# Altium schematic sheet Power.SchDoc(Power)
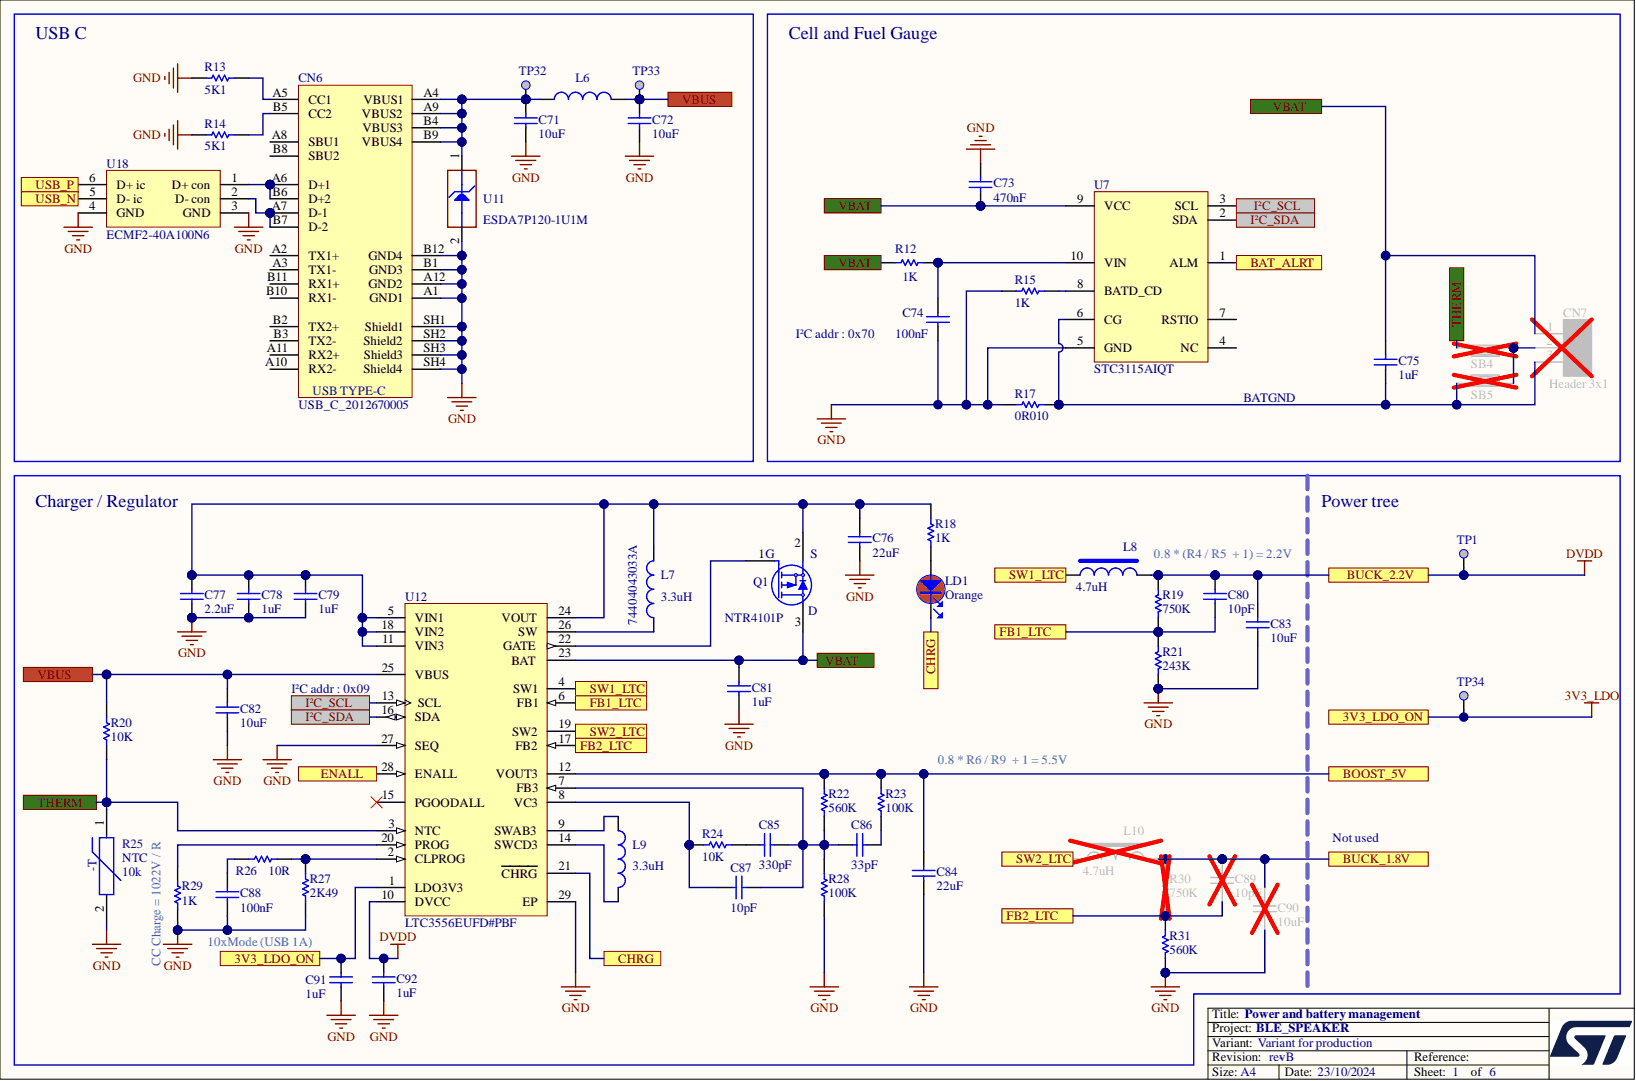
[diagram p0: Power.SchDoc]

## Parts
C71  value 10uF  [C0402]  (hidden comment: Capacitor)
C72  value 10uF  [C0402]  (hidden comment: Capacitor)
C73  value 470nF  [C0402]  (hidden comment: Capacitor)
C74  value 100nF  [C0201_H0P3]  (hidden comment: Capacitor)
C75  value 1uF  [C0402]  (hidden comment: Capacitor)
C76  value 22uF  [C0603_H0P8]  (hidden comment: Capacitor)
C77  value 2.2uF  [C0402]  (hidden comment: Capacitor)
C78  value 1uF  [C0402]  (hidden comment: Capacitor)
C79  value 1uF  [C0402]  (hidden comment: Capacitor)
C80  value 10pF  [IND_0201_MURATA_GJM03_H0P3]  (hidden comment: Capacitor)
C81  value 1uF  [C0402]  (hidden comment: Capacitor)
C82  value 10uF  [C0402]  (hidden comment: Capacitor)
C83  value 10uF  [C0402]  (hidden comment: Capacitor)
C84  value 22uF  [C0603_H0P8]  (hidden comment: Capacitor)
C85  value 330pF  [C0402]  (hidden comment: Capacitor)
C86  value 33pF  [C0402]  (hidden comment: Capacitor)
C87  value 10pF  [IND_0201_MURATA_GJM03_H0P3]  (hidden comment: Capacitor)
C88  value 100nF  [C0201_H0P3]  (hidden comment: Capacitor)
C89  value 10pF  [IND_0201_MURATA_GJM03_H0P3]  (hidden comment: Capacitor)
C90  value 10uF  [C0402]  (hidden comment: Capacitor)
C91  value 1uF  [C0402]  (hidden comment: Capacitor)
C92  value 1uF  [C0402]  (hidden comment: Capacitor)
CN6  value USB_C_2012670005  [CON_USB-TYPEC_MOLEX_2012670005]  (hidden comment: USB_TYPE_C_Receptacle)
CN7  Header 3x1  [CON_988-JIUBABA_PHFS25-11-03-G0B115-T-1]
L6  BEAD  [FIL_0603_H0P65]  (value not drawn)
L7  value 3.3uH  Manufacturer_Part_Number: 74404043033A  [IND_4025_WURTH_74404043022A]  (hidden comment: Inductor)
L8  value 4.7uH  [IND_TAIYO-YUDEN_LSXND4040]  (hidden comment: INDUCTOR_POWER)
L9  value 3.3uH  [IND_4025_WURTH_74404043022A]  (hidden comment: Inductor)
L10  value 4.7uH  [IND_TAIYO-YUDEN_LSXND4040]  (hidden comment: INDUCTOR_POWER)
LD1  value Orange  [LED_0603_VISHAY_VLMO1300-GS08_ORANGE_1A2K_H0P8]  (hidden comment: LED_orange)
Q1  value NTR4101P  [MOS_SOT23]  (hidden comment: MOSFET_P-CHANNEL)
R12  value 1K  [R0402]  (hidden comment: Resistor)
R13  value 5K1  [R0402]  (hidden comment: Resistor)
R14  value 5K1  [R0402]  (hidden comment: Resistor)
R15  value 1K  [R0402]  (hidden comment: Resistor)
R17  value 0R010  [R1206_H0P65]  (hidden comment: Resistor)
R18  value 1K  [R0402]  (hidden comment: Resistor)
R19  value 750K  [R0402]  (hidden comment: Resistor)
R20  value 10K  [R0402]  (hidden comment: Resistor)
R21  value 243K  [R0402]  (hidden comment: Resistor)
R22  value 560K  [R0402]  (hidden comment: Resistor)
R23  value 100K  [R0402]  (hidden comment: Resistor)
R24  value 10K  [R0402]  (hidden comment: Resistor)
R25  NTC  value 10k  [NTC0201_H0P3]
R26  value 10R  [R0402]  (hidden comment: Resistor)
R27  value 2K49  [R0402]  (hidden comment: Resistor)
R28  value 100K  [R0402]  (hidden comment: Resistor)
R29  value 1K  [R0402]  (hidden comment: Resistor)
R30  value 750K  [R0402]  (hidden comment: Resistor)
R31  value 560K  [R0402]  (hidden comment: Resistor)
SB4  SB_ON  [SOLDER-BRIDGE_R0201_0R]  (value not drawn)
SB5  SB_ON  [SOLDER-BRIDGE_R0201_0R]  (value not drawn)
TP1  Test Point  [TP_SMD_1MM]  (value not drawn)  (no BOM)
TP32  Test Point  [TP_SMD_1MM]  (value not drawn)  (no BOM)
TP33  Test Point  [TP_SMD_1MM]  (value not drawn)  (no BOM)
TP34  Test Point  [TP_SMD_1MM]  (value not drawn)  (no BOM)
U7  STC3115AIQT  [ICC_DFN10_3X2_P050]
U11  value ESDA7P120-1U1M  Manufacturer_Part_Number: ESDA7P120-1U1M  [ESD_QFN1610_2N_1K_2A]  (hidden comment: ESD-TRANSIL)
U12  LTC3556EUFD#PBF  [LT-UFD-28_M]
U18  ECMF2-40A100N6  [ESD_UQFN-6L_1P45X1P4_P040]

## Ports
3V3_LDO_ON, BAT_ALRT, BOOST_5V, BUCK_1.8V, BUCK_2.2V, CHRG, ENALL, FB1_LTC, FB2_LTC, I²C_SCL, I²C_SDA, SW1_LTC, SW2_LTC, THERM, USB_N, USB_P, VBAT, VBUS

## Nets
GND: C71.1 C72.1 C73.1 C74.2 C76.1 C77.1 C78.1 C79.2 C81.2 C82.1 C83.1 C84.1 C88.2 C90.1 C91.2 C92.2 CN6.A1(GND1) CN6.A12(GND2) CN6.B1(GND3) CN6.B12(GND4) CN6.SH1(Shield1) CN6.SH2(Shield2) CN6.SH3(Shield3) CN6.SH4(Shield4) R13.2 R14.1 R15.2 R17.1 R21.1 R25.2 R27.1 R28.1 R29.1 R31.1 U7.5(GND) U11.2 U12.27(SEQ) U12.29(EP) U18.3(GND) U18.4(GND)
3V3_LDO: C91.1 TP34.1 U12.1(LDO3V3) ; port 3V3_LDO_ON
BAT_ALRT: U7.1(ALM) ; port BAT_ALRT
BOOST_5V: C84.2 R22.2 R23.1 U12.12(VOUT3) ; port BOOST_5V
BUCK_1.8V: C89.1 C90.2 L10.1 R30.1 ; port BUCK_1.8V
CHRG: LD1.2 U12.21(CHRG) ; port CHRG
DVDD: C80.1 C83.2 C92.1 L8.1 R19.1 TP1.1 U12.10(DVCC) ; port BUCK_2.2V
ENALL: U12.28(ENALL) ; port ENALL
FB1_LTC: C80.2 R19.2 R21.2 U12.6(FB1) ; port FB1_LTC
FB2_LTC: C89.2 R30.2 R31.2 U12.17(FB2) ; port FB2_LTC
I²C_SCL: U7.3(SCL) U12.13(SCL) ; port I²C_SCL
I²C_SDA: U7.2(SDA) U12.16(SDA) ; port I²C_SDA
SW1_LTC: L8.2 U12.4(SW1) ; port SW1_LTC
SW2_LTC: L10.2 U12.19(SW2) ; port SW2_LTC
THERM: R20.1 R25.1 SB4.1 U12.3(NTC) ; port THERM
USB_N: U18.5(D-_ic) ; port USB_N
USB_P: U18.6(D+_ic) ; port USB_P
VBAT: C73.2 C75.1 C81.1 CN7.1 Q1.3 R12.2 U7.9(VCC) U12.23(BAT) ; port VBAT
VBUS: C72.2 C82.2 L6.2 R20.2 TP33.1 U12.25(VBUS) ; port VBUS
NetC71_2: C71.2 CN6.A4(VBUS1) CN6.A9(VBUS2) CN6.B4(VBUS3) CN6.B9(VBUS4) L6.1 TP32.1 U11.1
NetC74_1: C74.1 R12.1 U7.10(VIN)
NetC75_2: C75.2 CN7.3 R17.2 SB5.2 U7.6(CG)
NetC76_2: C76.2 C77.2 C78.2 C79.1 L7.2 Q1.2 R18.2 U12.5(VIN1) U12.11(VIN3) U12.18(VIN2) U12.24(VOUT)
NetC85_1: C85.1 R24.2
NetC85_2: C85.2 C86.2 C87.1 R22.1 R28.2 U12.7(FB3)
NetC86_1: C86.1 R23.2
NetC87_2: C87.2 R24.1 U12.8(VC3)
NetC88_1: C88.1 R26.1
NetCN6_A5: CN6.A5(CC1) R13.1
NetCN6_A6: CN6.A6(D+1) CN6.B6(D+2) U18.1(D+_con)
NetCN6_A7: CN6.A7(D-1) CN6.B7(D-2) U18.2(D-_con)
NetCN6_B5: CN6.B5(CC2) R14.2
NetCN7_2: CN7.2 SB4.2 SB5.1
NetL7_1: L7.1 U12.26(SW)
NetL9_1: L9.1 U12.9(SWAB3)
NetL9_2: L9.2 U12.14(SWCD3)
NetLD1_1: LD1.1 R18.1
NetQ1_1: Q1.1 U12.22(GATE)
NetR15_1: R15.1 U7.8(BATD_CD)
NetR26_2: R26.2 R27.2 U12.2(CLPROG)
NetR29_2: R29.2 U12.20(PROG)

Not connected: CN6 A2(TX1+) A3(TX1-) A8(SBU1) A10(RX2-) A11(RX2+) B2(TX2+) B3(TX2-) B8(SBU2) B10(RX1-) B11(RX1+); U7 4(NC) 7(RSTIO); U12 15(PGOODALL)

## Library data of the parts
C71 C72 C82 C83 C90 = MCD-03140: Capacitor, MLCC, 10uF, 6.3V, X5R, +/-20%, 0402, T=0.5mm; Manufacturer: MURATA; Manufacturer_Part_Number: GRM155R60J106ME05D; Part_Preference: Recommended; Component_Country_Of_Origin: China, Japan; Database Table Name: MCD_PARTS$; ID: 5256; ShowFields: YES; Component Kind: Standard; ComponentLink1URL: http://tunmcdsvn.tun.st.com/Component_Documentation_Library/Trunk/Capacitor/MURATA_GRM_Series; Internal_Part_Number: MCD-03140; Library Name: MCD_PARTS_database.DbLib; Order_Code_Digikey: 490-GRM155R60J106ME05DTR-ND; Order_Code_Farnell: 3784428; Order_Code_RS: N/A; Package: 0402; Part_Type: Capacitor; Status: Released
C73 = MCD-03075: Capacitor, MLCC, 470nF, 25V, X5R, +/-10%, 0402, T=0.5mm; Manufacturer: YAGEO; Manufacturer_Part_Number: CC0402KRX5R8BB474; Part_Preference: Recommended; Component_Country_Of_Origin: China, Taiwan; Database Table Name: MCD_PARTS$; ID: 5191; ShowFields: YES; Component Kind: Standard; ComponentLink1URL: http://tunmcdsvn.tun.st.com/Component_Documentation_Library/Trunk/Capacitor/YAGEO_X5R_Series/; Internal_Part_Number: MCD-03075; Library Name: MCD_PARTS_database.DbLib; Order_Code_Digikey: 13-CC0402KRX5R8BB474TR-ND; Order_Code_Farnell: 3871700; Order_Code_RS: N/A; Package: 0402; Part_Type: Capacitor; Status: Checked
C74 C88 = MCD-00697: Capacitor, MLCC, 100nF,10V, X5R, +/-10%, 0201, T=0.3mm; Manufacturer: TDK; Manufacturer_Part_Number: C0603X5R1A104K030BC; Part_Preference: Recommended; Component_Country_Of_Origin: Unknown; Database Table Name: MCD_PARTS$; ID: 3046; ShowFields: YES; Component Kind: Standard; ComponentLink1URL: http://tunmcdsvn.tun.st.com/Component_Documentation_Library/Trunk/Capacitor/TDK_MCC_Series; Internal_Part_Number: MCD-00697; Library Name: MCD_PARTS_database.DbLib; Order_Code_Digikey: 445-7318-1-ND; Order_Code_Farnell: 2524957; Order_Code_RS: N/A; Package: 0201; Part_Type: Capacitor; Status: Released
C75 C78 C79 C81 C91 C92 = MCD-01722: Capacitor, MLCC, 1uF, 10V, X5R, +/-10%, 0402, T=0.5mm; Manufacturer: YAGEO; Manufacturer_Part_Number: CC0402KRX5R6BB105; Part_Preference: Recommended; Component_Country_Of_Origin: China; Database Table Name: MCD_PARTS$; ID: 3822; ShowFields: YES; Component Kind: Standard; ComponentLink1URL: http://tunmcdsvn.tun.st.com/Component_Documentation_Library/Trunk/Capacitor/YAGEO_X5R_Series; Internal_Part_Number: MCD-01722; Library Name: MCD_PARTS_database.DbLib; Order_Code_Digikey: 311-1439-1-ND; Order_Code_Farnell: 1458896; Order_Code_RS: N/A; Package: 0402; Part_Type: Capacitor; Status: Released
C76 C84 = MCD-02607: Capacitor, MLCC, 22uF, 10V, X5R, +/-20%, 0603, T=0.8mm; Manufacturer: YAGEO; Manufacturer_Part_Number: CC0603MRX5R6BB226; Part_Preference: Recommended; Component_Country_Of_Origin: Taiwan°; Database Table Name: MCD_PARTS$; ID: 4713; Order_Code_RS: 2006627; ShowFields: YES; Component Kind: Standard; ComponentLink1URL: http://tunmcdsvn.tun.st.com/Component_Documentation_Library/Trunk/Capacitor/YAGEO_X5R_Series; Internal_Part_Number: MCD-02607; Library Name: MCD_PARTS_database.DbLib; Order_Code_Digikey: 311-1819-2-ND; Order_Code_Farnell: N/A; Package: 0603; Part_Type: Capacitor; Status: Released
C77 = MCD-01823: Capacitor, MLCC, 2.2uF, 6V3, X5R,+/-10%, 0402, T=0.5mm; Manufacturer: MURATA; Manufacturer_Part_Number: GRM155R60J225KE95D; Part_Preference: Recommended; Partial_Alternate_Internal_Part_Number: MCD-03566; Component_Country_Of_Origin: Japan°°; Database Table Name: MCD_PARTS$; ID: 3923; Order_Code_RS: 172-6478; ShowFields: YES; Component Kind: Standard; ComponentLink1URL: http://tunmcdsvn.tun.st.com/Component_Documentation_Library/Trunk/Capacitor/MURATA_GRM_Series; Internal_Part_Number: MCD-01823; Library Name: MCD_PARTS_database.DbLib; Order_Code_Digikey: 490-12532-1-ND; Order_Code_Farnell: 2695865; Package: 0402; Part_Type: Capacitor; Status: Released
C80 C87 C89 = MCD-04016: Capacitor, MLCC, 10pF, 25V, NPO, +/-5%, 0201, T=0.3mm; Manufacturer: MURATA; Manufacturer_Part_Number: GJM0335C1E100JB01; Part_Preference: Recommended; Component_Country_Of_Origin: Japan, Singapore; Database Table Name: MCD_PARTS$; ID: 6132; ShowFields: YES; Component Kind: Standard; ComponentLink1URL: http://tunmcdsvn.tun.st.com/Component_Documentation_Library/Trunk/Capacitor/MURATA_GJM_Series/GJM0335C1E100GB01D; Internal_Part_Number: MCD-04016; Library Name: MCD_PARTS_database.DbLib; Order_Code_Digikey: 490-13312-6-ND; Order_Code_Farnell: 3346154; Order_Code_RS: N/A; Package: 0201; Part_Type: Capacitor; Status: Checked
C85 = MCD-01579: Capacitor, MLCC, 330pF, 50V, NPO, +/-5%, 0402, T=0.5mm; Manufacturer: YAGEO; Manufacturer_Part_Number: CC0402JRNPO9BN331; Part_Preference: Recommended; Component_Country_Of_Origin: China; Database Table Name: MCD_PARTS$; ID: 3679; ShowFields: YES; Component Kind: Standard; ComponentLink1URL: http://tunmcdsvn.tun.st.com/Component_Documentation_Library/Trunk/Capacitor/YAGEO_NP0_Series; Internal_Part_Number: MCD-01579; Library Name: MCD_PARTS_database.DbLib; Order_Code_Digikey: 311-1667-1-ND; Order_Code_Farnell: N/A; Order_Code_RS: N/A; Package: 0402; Part_Type: Capacitor; Status: Released
C86 = MCD-00277: Capacitor, MLCC, 33pF, 50V, NPO,+/-5%, 0402, T=0.5mm; Manufacturer: YAGEO; Manufacturer_Part_Number: CC0402JRNPO9BN330; Part_Preference: Recommended; Component_Country_Of_Origin: China; Database Table Name: MCD_PARTS$; ID: 2592; ShowFields: YES; Component Kind: Standard; ComponentLink1URL: http://tunmcdsvn.tun.st.com/Component_Documentation_Library/Trunk/Capacitor/YAGEO_NP0_Series; Internal_Part_Number: MCD-00277; Library Name: MCD_PARTS_database.DbLib; Order_Code_Digikey: 311-1020-1-ND; Order_Code_Farnell: 3019196; Order_Code_RS: N/A; Package: 0402; Part_Type: Capacitor; Status: Released
CN6 = MCD-02421: USB_TypeC, 24 pins, RA, SMD, T=3.71mm (USB Certified 3.1); Manufacturer: MOLEX; Manufacturer_Part_Number: 2012670005; Part_Preference: Recommended; Part_Type: Connector; Component_Country_Of_Origin: Unknown; Database Table Name: MCD_PARTS$; ID: 4527; Order_Code_RS: 176-8434; ShowFields: YES; Component Kind: Standard; ComponentLink1URL: http://tunmcdsvn.tun.st.com/Component_Documentation_Library/Trunk/Connector/MOLEX_201267_Series; Internal_Part_Number: MCD-02421; Library Name: MCD_PARTS_database.DbLib; Order_Code_Digikey: WM17992TR-ND; Order_Code_Farnell: 2990523; Status: Released
CN7 = MCD-00315: Header, 3x1, 2.54mm, VR, TH, T=6mm/3mm; Manufacturer: JIUBABA; Manufacturer_Part_Number: PHFS25-11-03-G0B115-T-1; Part_Preference: Alternate; Part_Type: Connector; Alternate_EMS: A; Component_Country_Of_Origin: Unknown; Database Table Name: MCD_PARTS$; ID: 2555; ShowFields: YES; Component Kind: Standard; ComponentLink1URL: http://tunmcdsvn.tun.st.com/Component_Documentation_Library/Trunk/Connector/JIUBABA_PHFS25-11-03-G0B115-T-1/; Internal_Part_Number: MCD-00315; Library Name: MCD_PARTS_database.DbLib; Order_Code_Digikey: N/A; Order_Code_Farnell: N/A; Order_Code_RS: N/A; Status: Released; Value: Header 3x1
L6 = MCD-00305: Ferrite bead, 26R @ 100Mhz, +/-25%, 6000mA, 0R007, 0603, T=0.75mm; Manufacturer: MURATA; Manufacturer_Part_Number: BLM18KG260TN1; Part_Preference: Recommended; Part_Type: Inductor; Component_Country_Of_Origin: Japan°°; Database Table Name: MCD_PARTS$; ID: 2564; Order_Code_RS: 747-4723; ShowFields: YES; Component Kind: Standard; ComponentLink1URL: http://tunmcdsvn.tun.st.com/Component_Documentation_Library/Trunk/Inductor/MURATA_BLM_Series; Internal_Part_Number: MCD-00305; Library Name: MCD_PARTS_database.DbLib; Order_Code_Digikey: 490-5252-2-ND; Order_Code_Farnell: 1781087; Package: 0603; Status: Released; Value: 26R @ 100Mhz
L7 = MCD-02242: Inductor, 3.3uH, +/-30%, 3,83A, 0R023, 4x4mm, T=2.5mm; Manufacturer: WURTH ELEKTRONIK; Part_Preference: Recommended; Component_Country_Of_Origin: Unknown; Database Table Name: MCD_PARTS$; ID: 4342; ShowFields: YES; Component Kind: Standard; ComponentLink1URL: http://tunmcdsvn.tun.st.com/Component_Documentation_Library/Trunk/Inductor/WURTH_ELEKTRONIK_74404043022A; Internal_Part_Number: MCD-02242; Library Name: MCD_PARTS_database.DbLib; Order_Code_Digikey: 732-10754-2-ND; Order_Code_Farnell: 2749069; Order_Code_RS: N/A; Package: 4x4mm; Part_Type: Inductor; Status: Released
L8 L10 = MCD-04038: Inductor, 4.7uH, +/-20%, 1.2A, 114mR, 4040, T=1.2mm; Manufacturer: TAIYO YUDEN; Manufacturer_Part_Number: LSXND4040MKL4R7MDG; Part_Preference: Recommended; Component_Country_Of_Origin: Unknown; Database Table Name: MCD_PARTS$; ID: 6154; ShowFields: YES; Component Kind: Standard; ComponentLink1URL: http://tunmcdsvn.tun.st.com/Component_Documentation_Library/Trunk/Inductor/TAIYO_YUDEN_NR_Series/LSXND4040MKL4R7MDG; Internal_Part_Number: MCD-04038; Library Name: MCD_PARTS_database.DbLib; Order_Code_Digikey: 587-LSXND4040MKL4R7MDGTR-ND; Order_Code_Farnell: N/A; Order_Code_RS: N/A; Package: 4040; Part_Type: Inductor; Status: Checked
L9 = MCD-02242: Inductor, 3.3uH, +/-30%, 3,83A, 0R023, 4x4mm, T=2.5mm; Manufacturer: WURTH ELEKTRONIK; Manufacturer_Part_Number: 74404043033A; Part_Preference: Recommended; Component_Country_Of_Origin: Unknown; Database Table Name: MCD_PARTS$; ID: 4342; ShowFields: YES; Component Kind: Standard; ComponentLink1URL: http://tunmcdsvn.tun.st.com/Component_Documentation_Library/Trunk/Inductor/WURTH_ELEKTRONIK_74404043022A; Internal_Part_Number: MCD-02242; Library Name: MCD_PARTS_database.DbLib; Order_Code_Digikey: 732-10754-2-ND; Order_Code_Farnell: 2749069; Order_Code_RS: N/A; Package: 4x4mm; Part_Type: Inductor; Status: Released
LD1 = MCD-00591: LED, Orange, 2V, 20mA, 0603; Manufacturer: VISHAY; Manufacturer_Part_Number: VLMO1300-GS08; Part_Preference: Recommended; Part_Type: Display; Component_Country_Of_Origin: China; Database Table Name: MCD_PARTS$; ID: 3153; Order_Code_RS: 7730446; ShowFields: YES; Component Kind: Standard; ComponentLink1URL: http://tunmcdsvn.tun.st.com/Component_Documentation_Library/Trunk/Display/VISHAY_VLMO1300-GS08; Internal_Part_Number: MCD-00591; Library Name: MCD_PARTS_database.DbLib; Order_Code_Digikey: VLMO1300-GS08CT-ND; Order_Code_Farnell: 2251473; Package: 0603; Status: Released
Q1 = MCD-02288: Transistor, MOSFET, P-CH, -20V, -3.2A, SOT23-3; Manufacturer: ON SEMICONDUCTOR; Manufacturer_Part_Number: NTR4101PT1G; Part_Preference: Recommended; Component_Country_Of_Origin: China°; Database Table Name: MCD_PARTS$; ID: 4388; ShowFields: YES; Component Kind: Standard; ComponentLink1URL: http://tunmcdsvn.tun.st.com/Component_Documentation_Library/Trunk/Transistor/ON_SEMICONDUCTOR_NTR4101PT1G; Internal_Part_Number: MCD-02288; Library Name: MCD_PARTS_database.DbLib; Order_Code_Digikey: NTR4101PT1GOSCT-ND; Order_Code_Farnell: 1431303; Order_Code_RS: N/A; Package: SOT23-3; Part_Type: Transistor; Status: Released
R12 R15 R18 R29 = MCD-00061: Resistor, 1K, 0402, +/-1%,1/16W; Manufacturer: YAGEO; Manufacturer_Part_Number: RC0402FR-071KL; Part_Preference: Recommended; Component_Country_Of_Origin: China; Database Table Name: MCD_PARTS$; ID: 2532; Order_Code_RS: 9239235; ShowFields: YES; Component Kind: Standard; ComponentLink1URL: http://tunmcdsvn.tun.st.com/Component_Documentation_Library/Trunk/Resistor/YAGEO_RC_L_Series; Internal_Part_Number: MCD-00061; Library Name: MCD_PARTS_database.DbLib; Order_Code_Digikey: 311-1.00KLRCT-ND; Order_Code_Farnell: 9239235; Package: 0402; Part_Type: Resistor; Status: Released
R13 R14 = MCD-00333: Resistor, 5K1, 0402, +/-1%, 1/16W; Manufacturer: YAGEO; Manufacturer_Part_Number: RC0402FR-075K1L; Part_Preference: Recommended; Component_Country_Of_Origin: China; Database Table Name: MCD_PARTS$; ID: 2944; ShowFields: YES; Component Kind: Standard; ComponentLink1URL: http://tunmcdsvn.tun.st.com/Component_Documentation_Library/Trunk/Resistor/YAGEO_RC_L_Series; Internal_Part_Number: MCD-00333; Library Name: MCD_PARTS_database.DbLib; Order_Code_Digikey: 311-5.10KLRCT-ND; Order_Code_Farnell: 1458805; Order_Code_RS: N/A; Package: 0402; Part_Type: Resistor; Status: Released
R17 = MCD-01029: Resistor, 0R010, 1206 ,+/-1%, 1W; Manufacturer: PANASONIC; Manufacturer_Part_Number: ERJ8CWFR015V; Part_Preference: Recommended; Component_Country_Of_Origin: Japan; Database Table Name: MCD_PARTS$; ID: 2994; Order_Code_RS: 763-8744; ShowFields: YES; Component Kind: Standard; ComponentLink1URL: http://tunmcdsvn.tun.st.com/Component_Documentation_Library/Trunk/Resistor/PANASONIC_ERJ_Series; Internal_Part_Number: MCD-01029; Library Name: MCD_PARTS_database.DbLib; Order_Code_Digikey: P0.015BVCT-ND; Order_Code_Farnell: 2145288; Package: 1206; Part_Type: Resistor; Status: Checked
R19 R30 = MCD-00523: Resistor, 750K, 0402, +/-1%, 1/16W; Manufacturer: YAGEO; Manufacturer_Part_Number: RC0402FR-07750KL; Part_Preference: Recommended; Component_Country_Of_Origin: China; Database Table Name: MCD_PARTS$; ID: 3219; ShowFields: YES; Component Kind: Standard; ComponentLink1URL: http://tunmcdsvn.tun.st.com/Component_Documentation_Library/Trunk/Resistor/YAGEO_RC_L_Series; Internal_Part_Number: MCD-00523; Library Name: MCD_PARTS_database.DbLib; Order_Code_Digikey: YAG3228CT-ND; Order_Code_Farnell: 1458827; Order_Code_RS: N/A; Package: 0402; Part_Type: Resistor; Status: Released
R20 R24 = MCD-00053: Resistor, 10K, 0402, +/-1%, 1/16W; BMS_Part_Number: 9131590; Manufacturer: YAGEO; Manufacturer_Part_Number: RC0402FR-0710KL; Part_Preference: Recommended; Component_Country_Of_Origin: China; Database Table Name: MCD_PARTS$; ID: 2539; Order_Code_RS: 9239359; ShowFields: YES; Component Kind: Standard; ComponentLink1URL: http://tunmcdsvn.tun.st.com/Component_Documentation_Library/Trunk/Resistor/YAGEO_RC_L_Series; Internal_Part_Number: MCD-00053; Library Name: MCD_PARTS_database.DbLib; Order_Code_Digikey: 311-10.0KLRCT-ND; Order_Code_Farnell: 9239359; Package: 0402; Part_Type: Resistor; Status: Released
R21 = MCD-00479: Resistor, 430K, 0402, +/-1%, 1/16W; Manufacturer: YAGEO; Manufacturer_Part_Number: RC0402FR-07430KL; Part_Preference: Recommended; Component_Country_Of_Origin: China; Database Table Name: MCD_PARTS$; ID: 2799; ShowFields: YES; Component Kind: Standard; ComponentLink1URL: http://tunmcdsvn.tun.st.com/Component_Documentation_Library/Trunk/Resistor/YAGEO_RC_L_Series; Internal_Part_Number: MCD-00479; Library Name: MCD_PARTS_database.DbLib; Order_Code_Digikey: 311-430KLRCT-ND; Order_Code_Farnell: N/A; Order_Code_RS: N/A; Package: 0402; Part_Type: Resistor; Status: Released
R22 R31 = MCD-00495: Resistor, 560K, 0402, +/-1%, 1/16W; Manufacturer: YAGEO; Manufacturer_Part_Number: RC0402FR-07560KL; Part_Preference: Recommended; Component_Country_Of_Origin: China; Database Table Name: MCD_PARTS$; ID: 2779; ShowFields: YES; Component Kind: Standard; ComponentLink1URL: http://tunmcdsvn.tun.st.com/Component_Documentation_Library/Trunk/Resistor/YAGEO_RC_L_Series; Internal_Part_Number: MCD-00495; Library Name: MCD_PARTS_database.DbLib; Order_Code_Digikey: 311-560KLRCT-ND; Order_Code_Farnell: 1458810; Order_Code_RS: N/A; Package: 0402; Part_Type: Resistor; Status: Released
R23 R28 = MCD-00059: Resistor, 100K, 0402, +/-1%, 1/16W; Manufacturer: YAGEO; Manufacturer_Part_Number: RC0402FR-07100KL; Part_Preference: Recommended; Partial_Alternate_Internal_Part_Number: MCD-03301; Component_Country_Of_Origin: China; Database Table Name: MCD_PARTS$; ID: 2533; Order_Code_RS: 2421891; ShowFields: YES; Component Kind: Standard; ComponentLink1URL: http://tunmcdsvn.tun.st.com/Component_Documentation_Library/Trunk/Resistor/YAGEO_RC_L_Series; Internal_Part_Number: MCD-00059; Library Name: MCD_PARTS_database.DbLib; Order_Code_Digikey: 311-100KLRTR-ND; Order_Code_Farnell: 2421891; Package: 0402; Part_Type: Resistor; Status: Released
R25 = MCD-03760: NTC Thermistor, 10k, 0201, +/-1%@25°C, 100mW; Manufacturer: TDK; Manufacturer_Part_Number: NTCG063JF103FTDS; Part_Preference: Recommended; Part_Type: Resistor; Component_Country_Of_Origin: Japan°; Database Table Name: MCD_PARTS$; ID: 5876; ShowFields: YES; Component Kind: Standard; ComponentLink1URL: http://tunmcdsvn.tun.st.com/Component_Documentation_Library/Trunk/Resistor/TDK_NTCG_Series/NTCG063JF103FTDS; Internal_Part_Number: MCD-03760; Library Name: MCD_PARTS_database.DbLib; Order_Code_Digikey: N/A; Order_Code_Farnell: 4154099; Order_Code_RS: N/A; Package: 0201; Status: Checked
R26 = MCD-00358: Resistor, 10R, 0402, +/-1%, 1/16W; Manufacturer: YAGEO; Manufacturer_Part_Number: RC0402FR-0710RL; Part_Preference: Recommended; Component_Country_Of_Origin: China; Database Table Name: MCD_PARTS$; ID: 2919; Order_Code_RS: 618-5848; ShowFields: YES; Component Kind: Standard; ComponentLink1URL: http://tunmcdsvn.tun.st.com/Component_Documentation_Library/Trunk/Resistor/YAGEO_RC_L_Series; Internal_Part_Number: MCD-00358; Library Name: MCD_PARTS_database.DbLib; Order_Code_Digikey: 311-10.0LRCT-ND; Order_Code_Farnell: 9238999; Package: 0402; Part_Type: Resistor; Status: Released
R27 = MCD-00183: Resistor, 2K49, 0402, +/-1%, 1/16W; Manufacturer: YAGEO; Manufacturer_Part_Number: RC0402FR-072K49L; Part_Preference: Recommended; Component_Country_Of_Origin: China; Database Table Name: MCD_PARTS$; ID: 2687; ShowFields: YES; Component Kind: Standard; ComponentLink1URL: http://tunmcdsvn.tun.st.com/Component_Documentation_Library/Trunk/Resistor/YAGEO_RC_L_Series; Internal_Part_Number: MCD-00183; Library Name: MCD_PARTS_database.DbLib; Order_Code_Digikey: 311-2.49KLRCT-ND; Order_Code_Farnell: 1799190; Order_Code_RS: N/A; Package: 0402; Part_Type: Resistor; Status: Released
SB4 SB5 = MCD-03219: Solder Bridge ON, 0R, 0201, 1/20W; Manufacturer: YAGEO; Manufacturer_Part_Number: RC0201JR-070RL; Part_Preference: Recommended; Part_Type: Misc; Component_Country_Of_Origin: China; Database Table Name: MCD_PARTS$; ID: 5335; Order_Code_RS: 198-7623; ShowFields: YES; Component Kind: Standard; ComponentLink1URL: http://tunmcdsvn.tun.st.com/Component_Documentation_Library/Trunk/Resistor/YAGEO_RC_L_Series; Internal_Part_Number: MCD-03219; Library Name: MCD_PARTS_database.DbLib; Order_Code_Digikey: 311-0.0NTR-ND; Order_Code_Farnell: 1458895; Package: SB0201; Status: Checked; Value: 0R
TP1 TP32-TP34 = MCD-00074: Test Point 1mm; Part_Preference: Recommended; Part_Type: Misc; Component_Country_Of_Origin: Unknown; Database Table Name: MCD_PARTS$; ID: 2518; ShowFields: YES; ComponentLink1URL: http://tunmcdsvn.tun.st.com/Component_Documentation_Library/Trunk/Misc/; Internal_Part_Number: MCD-00074; Library Name: MCD_PARTS_database.DbLib; Manufacturer: N/A; Manufacturer_HQ_COO: Unknown; Manufacturer_Part_Number: N/A; Order_Code_Digikey: N/A; Order_Code_Farnell: N/A; Order_Code_RS: N/A; Status: Released; Value: Test Point 1mm
U7 = MCD-01488: IC, Gas gauge IC with alarm output for handheld applications; BMS_Part_Number: 9176333; Manufacturer: STMICROELECTRONICS; Manufacturer_HQ_COO: EU; Part_Preference: Recommended; Component_Country_Of_Origin: Philippines; Database Table Name: MCD_PARTS$; ID: 3588; Order_Code_RS: 189-1867; ShowFields: YES; Component Kind: Standard; ComponentLink1URL: http://tunmcdsvn.tun.st.com/Component_Documentation_Library/Trunk/IC/STMICROELECTRONICS_STC3115AIQT; Internal_Part_Number: MCD-01488; Library Name: MCD_PARTS_database.DbLib; Manufacturer_Part_Number: STC3115AIQT; Order_Code_Digikey: 497-15077-2-ND; Order_Code_Farnell: 2770310; Package: DFN-10; Part_Type: IC; Status: Checked; Value: STC3115AIQT
U11 = MCD-01026: Diode, ESD TVS, 5V5, 1.5uA, 6V8, QFN1610; BMS_Part_Number: 9179046; Manufacturer: STMICROELECTRONICS; Manufacturer_HQ_COO: EU; Part_Preference: Recommended; Component_Country_Of_Origin: China; Database Table Name: MCD_PARTS$; ID: 2997; Order_Code_RS: 190-7605; ShowFields: YES; Component Kind: Standard; ComponentLink1URL: http://tunmcdsvn.tun.st.com/Component_Documentation_Library/Trunk/Diode/STMICROELECTRONICS_ESDA7P120-1U1M; Internal_Part_Number: MCD-01026; Library Name: MCD_PARTS_database.DbLib; Order_Code_Digikey: 497-17159-2-ND; Order_Code_Farnell: 3130027; Package: QFN1610; Part_Type: Diode; Status: Released
U12 = CMP-0478-00300-1: High Efficiency USB Power Manager with Dual Buck and Buck-Boost DC/DC, 4.35 to 5.5 V Vin, 28-pin QFN (UFD-28), -40 to 85 degC, Pb-Free; Manufacturer: Analog Devices / Linear Technology; Number of Cells: 1; Number of Outputs: 3; Vin Max(V): 5.5; Vin Min(V): 4.35; Code_JEDEC: MO-220; Component Kind: Standard; ComponentLink1Description: Manufacturer URL; ComponentLink1URL: http://www.linear.com/; ComponentLink2Description: Datasheet; ComponentLink2URL: http://cds.linear.com/docs/en/datasheet/3556f.pdf; ComponentLink3Description: Package Specification; ComponentLink3URL: http://cds.linear.com/docs/en/packaging/QFN_28_05-08-1712.pdf; Library Name: PoC_BLE.IntLib; Manufacturer_Part_Number: LTC3556EUFD#PBF; Mounting Technology: Surface Mount; PackageDescription: 28-Pin Plastic QFN, Body 5 x 4 mm, Pitch 0.5 mm; PackageReference: UFD-28; PackageVersion: Rev. B, 06/2010; PartNumber: LTC3556EUFD#PBF; RoHS: TRUE; Value: LTC3556EUFD
U18 = MCD-03060: IC, ESD protection and Common Filter High Speed serial Interfaces, 10.7 GHz; Manufacturer: STMICROELECTRONICS; Part_Preference: Recommended; Component_Country_Of_Origin: China; Database Table Name: MCD_PARTS$; ID: 5176; ShowFields: YES; Component Kind: Standard; ComponentLink1URL: http://tunmcdsvn.tun.st.com/Component_Documentation_Library/Trunk/IC/STMICROELECTRONICS_ECMF2-40A100N6; Internal_Part_Number: MCD-03060; Library Name: MCD_PARTS_database.DbLib; Manufacturer_Part_Number: ECMF2-40A100N6; Order_Code_Digikey: 497-ECMF2-40A100N6; Order_Code_Farnell: 3993603; Order_Code_RS: N/A; Package: uQFN-6; Part_Type: IC; Status: Released; Value: ECMF2-40A100N6
